# Altium schematic sheet Power.SchDoc(Power)
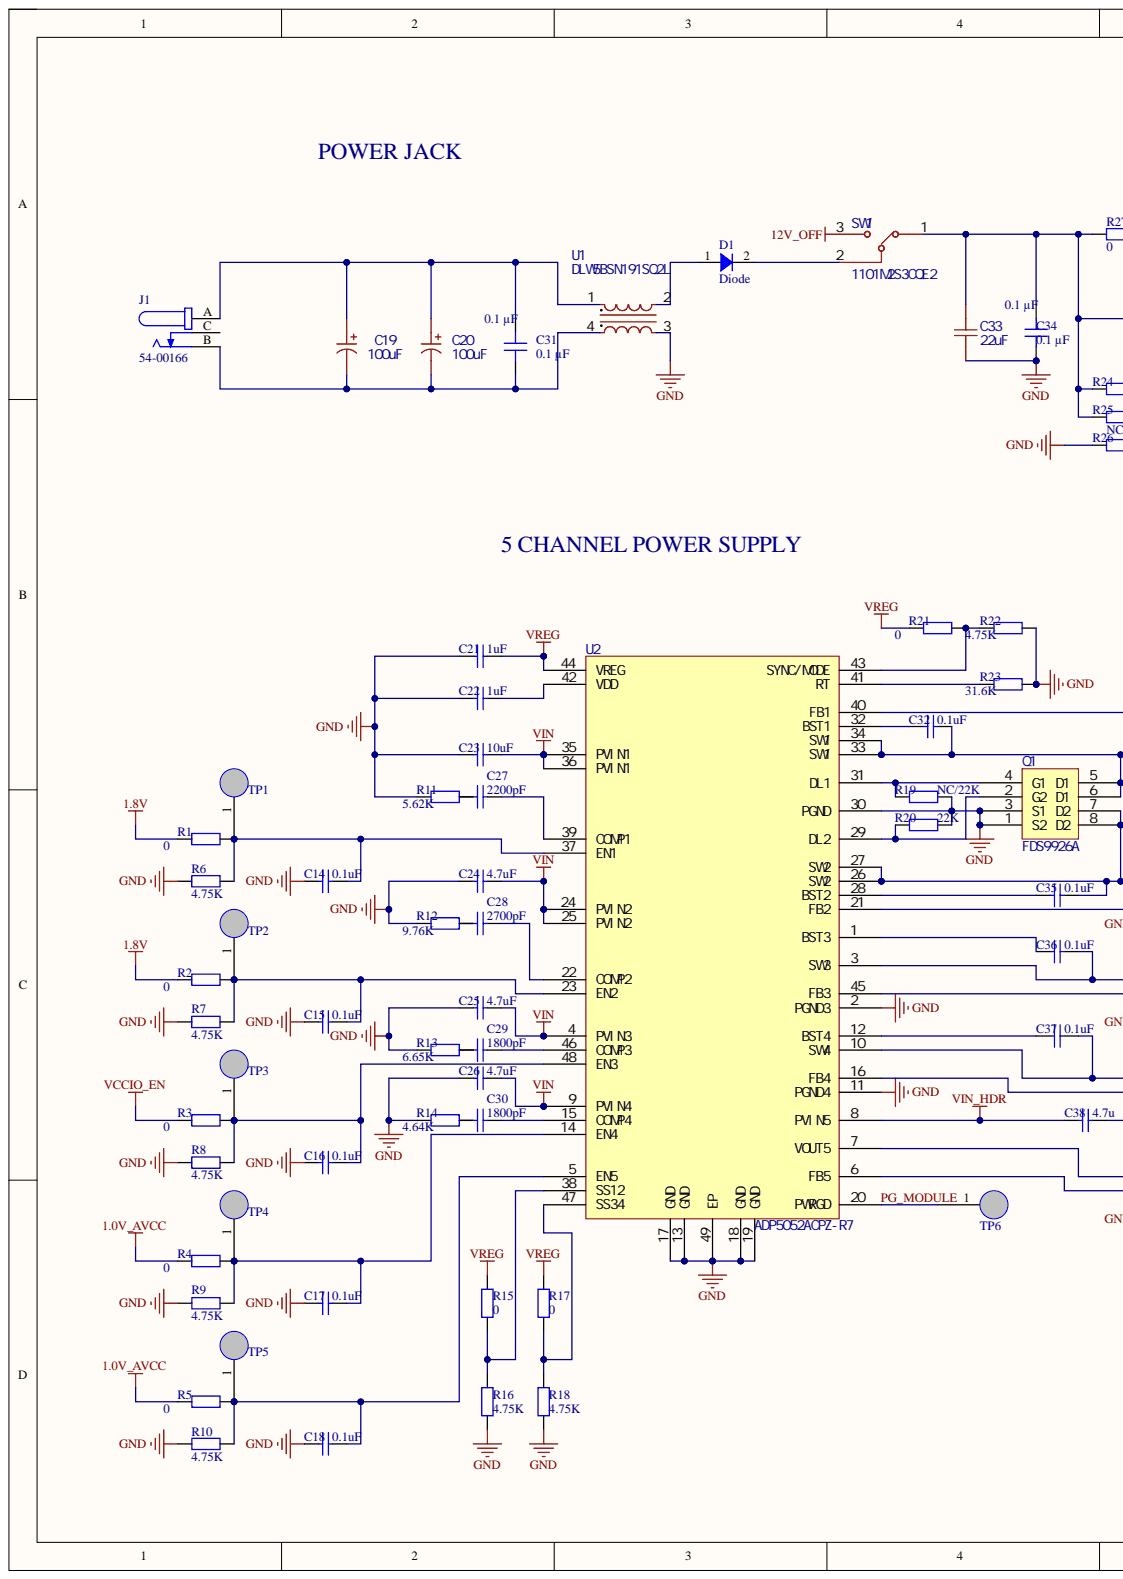
[diagram p0: Power.SchDoc, left]
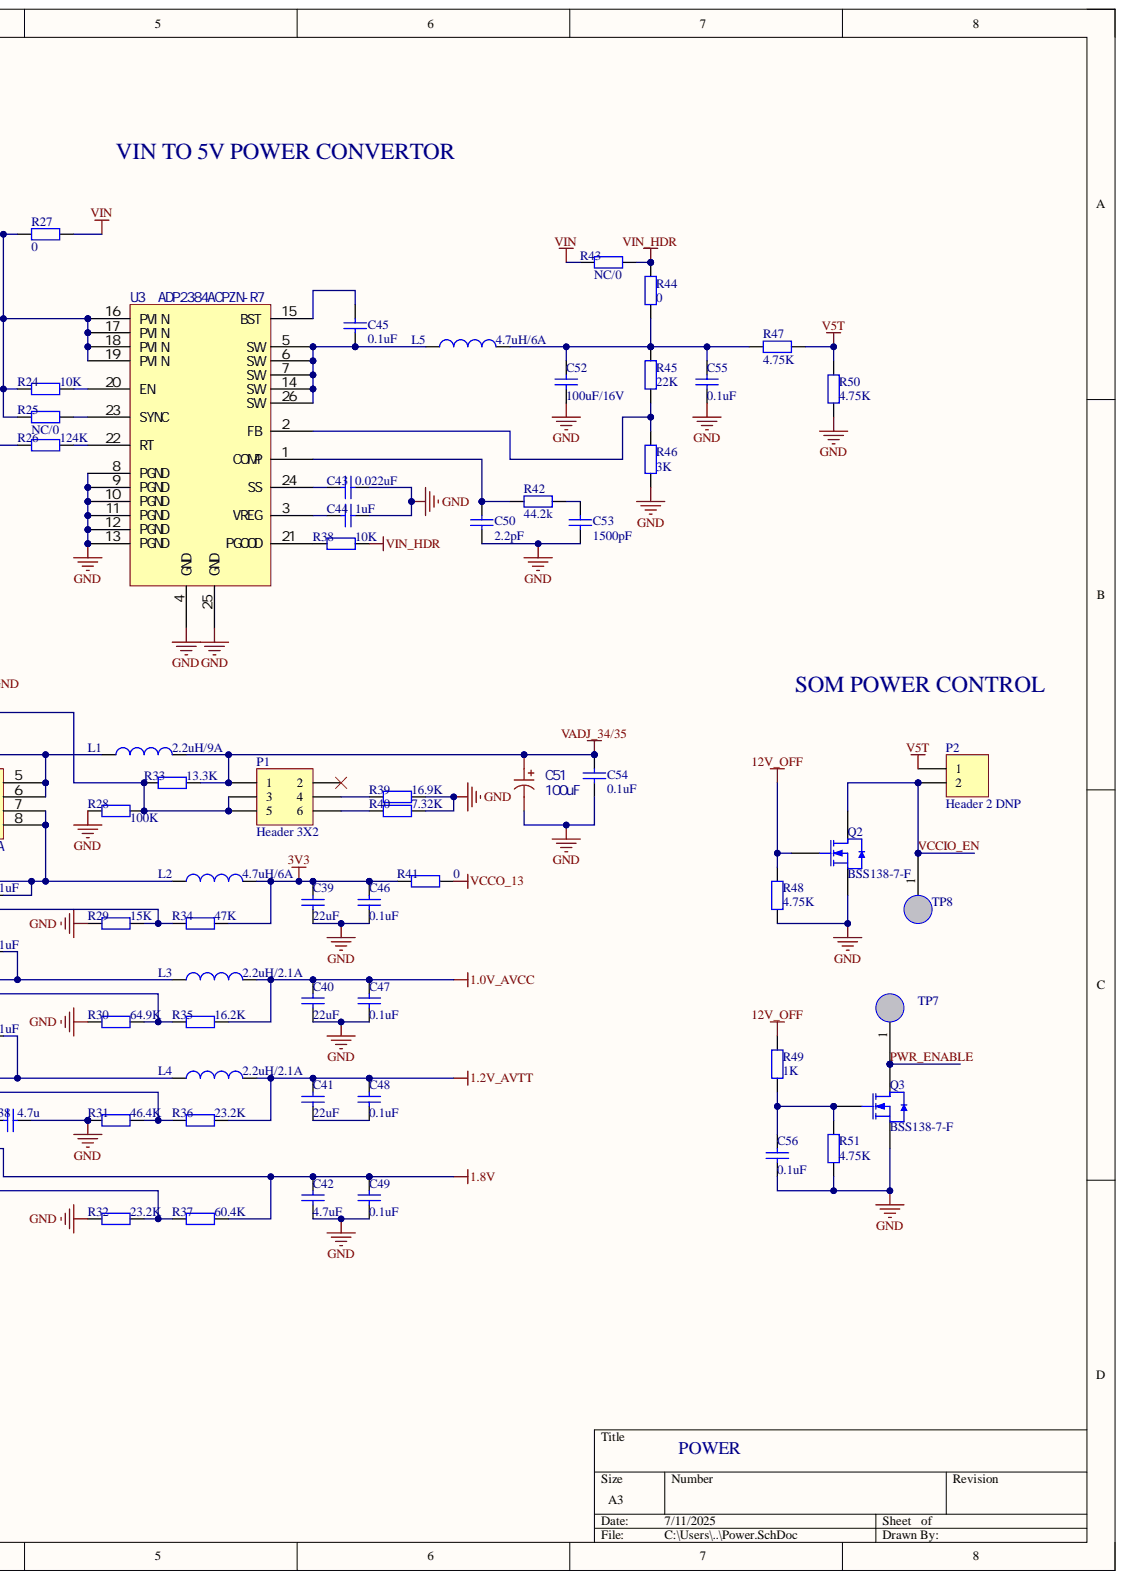
[diagram p1: Power.SchDoc, right]

## Parts
C14  value 0.1uF  [0603_C]  (hidden comment: Cap)
C15  value 0.1uF  [0603_C]  (hidden comment: Cap)
C16  value 0.1uF  [0603_C]  (hidden comment: Cap)
C17  value 0.1uF  [0603_C]  (hidden comment: Cap)
C18  value 0.1uF  [0603_C]  (hidden comment: Cap)
C19  100uF  [CAP-SMD_BD6.3-L6.6-W6.6-LS7.3-FD-H7.7]
C20  100uF  [CAP-SMD_BD6.3-L6.6-W6.6-LS7.3-FD-H7.7]
C21  value 1uF  [0603_C]  (hidden comment: Cap)
C22  value 1uF  [0603_C]  (hidden comment: Cap)
C23  value 10uF  [0603_C]  (hidden comment: Cap)
C24  value 4.7uF  [0603_C]  (hidden comment: Cap)
C25  value 4.7uF  [0603_C]  (hidden comment: Cap)
C26  value 4.7uF  [0603_C]  (hidden comment: Cap)
C27  value 2200pF  [0603_C]  (hidden comment: Cap)
C28  value 2700pF  [0603_C]  (hidden comment: Cap)
C29  value 1800pF  [0603_C]  (hidden comment: Cap)
C30  value 1800pF  [0603_C]  (hidden comment: Cap)
C31  0.1 µF  [CAP 0603_1608]
C32  value 0.1uF  [0603_C]  (hidden comment: Cap)
C33  22uF  [1206_C]
C34  0.1 µF  [CAP 0603_1608]
C35  value 0.1uF  [0603_C]  (hidden comment: Cap)
C36  value 0.1uF  [0603_C]  (hidden comment: Cap)
C37  value 0.1uF  [0603_C]  (hidden comment: Cap)
C38  value 4.7u  [0603_C]  (hidden comment: Cap)
C39  value 22uF  [0603_C]  (hidden comment: Cap)
C40  value 22uF  [0603_C]  (hidden comment: Cap)
C41  value 22uF  [0603_C]  (hidden comment: Cap)
C42  value 4.7uF  [0603_C]  (hidden comment: Cap)
C43  value 0.022uF  [0603_C]  (hidden comment: Cap)
C44  value 1uF  [0603_C]  (hidden comment: Cap)
C45  value 0.1uF  [0603_C]  (hidden comment: Cap)
C46  value 0.1uF  [0603_C]  (hidden comment: Cap)
C47  value 0.1uF  [0603_C]  (hidden comment: Cap)
C48  value 0.1uF  [0603_C]  (hidden comment: Cap)
C49  value 0.1uF  [0603_C]  (hidden comment: Cap)
C50  value 2.2pF  [0603_C]  (hidden comment: Cap)
C51  100uF  [CAP-SMD_BD6.3-L6.6-W6.6-LS7.3-FD-H7.7]
C52  value 100uF/16V  [0603_C]  (hidden comment: Cap)
C53  value 1500pF  [0603_C]  (hidden comment: Cap)
C54  value 0.1uF  [0603_C]  (hidden comment: Cap)
C55  value 0.1uF  [0603_C]  (hidden comment: Cap)
C56  value 0.1uF  [0603_C]  (hidden comment: Cap)
D1  Diode  [DO214AC SMA]
J1  54-00166  [54-00166]
L1  value 2.2uH/9A  [IND-SMD_L4.1-W4.1_SPM40XX]  (hidden comment: Inductor)
L2  value 4.7uH/6A  [IND-SMD_L7.0-W6.6]  (hidden comment: Inductor)
L3  value 2.2uH/2.1A  [IND-SMD_L4.1-W4.1_SPM40XX]  (hidden comment: Inductor)
L4  value 2.2uH/2.1A  [IND-SMD_L4.1-W4.1_SPM40XX]  (hidden comment: Inductor)
L5  value 4.7uH/6A  [IND-SMD_L7.0-W6.6]  (hidden comment: Inductor)
P1  Header 3X2  [HDR2X3]
P2  Header 2 DNP  [HDR1X2]
Q1  FDS9926A  [SOP-8]
Q2  BSS138-7-F  [SOT-23-3L]
Q3  BSS138-7-F  [SOT-23-3L]
R1  value 0  [0603_R]  (hidden comment: Res2)
R2  value 0  [0603_R]  (hidden comment: Res2)
R3  value 0  [0603_R]  (hidden comment: Res2)
R4  value 0  [0603_R]  (hidden comment: Res2)
R5  value 0  [0603_R]  (hidden comment: Res2)
R6  value 4.75K  [0603_R]  (hidden comment: Res2)
R7  value 4.75K  [0603_R]  (hidden comment: Res2)
R8  value 4.75K  [0603_R]  (hidden comment: Res2)
R9  value 4.75K  [0603_R]  (hidden comment: Res2)
R10  value 4.75K  [0603_R]  (hidden comment: Res2)
R11  value 5.62K  [0603_R]  (hidden comment: Res2)
R12  value 9.76K  [0603_R]  (hidden comment: Res2)
R13  value 6.65K  [0603_R]  (hidden comment: Res2)
R14  value 4.64K  [0603_R]  (hidden comment: Res2)
R15  value 0  [0603_R]  (hidden comment: Res2)
R16  value 4.75K  [0603_R]  (hidden comment: Res2)
R17  value 0  [0603_R]  (hidden comment: Res2)
R18  value 4.75K  [0603_R]  (hidden comment: Res2)
R19  value NC/22K  [0603_R]  (hidden comment: Res2)
R20  value 22K  [0603_R]  (hidden comment: Res2)
R21  value 0  [0603_R]  (hidden comment: Res2)
R22  value 4.75K  [0603_R]  (hidden comment: Res2)
R23  value 31.6K  [0603_R]  (hidden comment: Res2)
R24  value 10K  [0603_R]  (hidden comment: Res2)
R25  value NC/0  [0603_R]  (hidden comment: Res2)
R26  value 124K  [0603_R]  (hidden comment: Res2)
R27  value 0  [0603_R]  (hidden comment: Res2)
R28  value 100K  [0603_R]  (hidden comment: Res2)
R29  value 15K  [0603_R]  (hidden comment: Res2)
R30  value 64.9K  [0603_R]  (hidden comment: Res2)
R31  value 46.4K  [0603_R]  (hidden comment: Res2)
R32  value 23.2K  [0603_R]  (hidden comment: Res2)
R33  value 13.3K  [0603_R]  (hidden comment: Res2)
R34  value 47K  [0603_R]  (hidden comment: Res2)
R35  value 16.2K  [0603_R]  (hidden comment: Res2)
R36  value 23.2K  [0603_R]  (hidden comment: Res2)
R37  value 60.4K  [0603_R]  (hidden comment: Res2)
R38  value 10K  [0603_R]  (hidden comment: Res2)
R39  value 16.9K  [0603_R]  (hidden comment: Res2)
R40  value 7.32K  [0603_R]  (hidden comment: Res2)
R41  value 0  [0603_R]  (hidden comment: Res2)
R42  value 44.2k  [0603_R]  (hidden comment: Res2)
R43  value NC/0  [0603_R]  (hidden comment: Res2)
R44  value 0  [0603_R]  (hidden comment: Res2)
R45  value 22K  [0603_R]  (hidden comment: Res2)
R46  value 3K  [0603_R]  (hidden comment: Res2)
R47  value 4.75K  [0603_R]  (hidden comment: Res2)
R48  value 4.75K  [0603_R]  (hidden comment: Res2)
R49  value 1K  [0603_R]  (hidden comment: Res2)
R50  value 4.75K  [0603_R]  (hidden comment: Res2)
R51  value 4.75K  [0603_R]  (hidden comment: Res2)
SW1  1101M2S3CQE2  [SW-TH_1101M2S3CQE2]
TP1  TP  [Test-Point-0.5mm]
TP2  TP  [Test-Point-0.5mm]
TP3  TP  [Test-Point-0.5mm]
TP4  TP  [Test-Point-0.5mm]
TP5  TP  [Test-Point-0.5mm]
TP6  TP  [Test-Point-0.5mm]
TP7  TP  [Test-Point-0.5mm]
TP8  TP  [Test-Point-0.5mm]
U1  DLW5BSN191SQ2L  [FILTER-SMD_4P-L5.0-W5.0-TL_DLW5BSM302SQ2L]
U2  ADP5052ACPZ-R7  [LFCSP-48_L7.0-W7.0-P0.50-BL-EP5.5]
U3  ADP2384ACPZN-R7  [LFCSP-24_L4.0-W4.0-P0.50-BL_ADP238XACPZN-R7]

## Nets
1.0V_AVCC: C40.2 C47.2 L3.2 R4.2 R5.2 R35.1
1.2V_AVTT: C41.2 C48.2 L4.2 R36.1
1.8V: C42.2 C49.2 R1.2 R2.2 R37.1 U2.7(VOUT5)
3V3: C39.2 C46.2 L2.2 R34.1 R41.2
12V_OFF: Q2.1 R48.2 R49.2 SW1.3
GND: C14.1 C15.1 C16.1 C17.1 C18.1 C21.1 C22.1 C23.1 C24.1 C25.1 C26.1 C33.1 C34.1 C38.2 C39.1 C40.1 C42.1 C43.2 C44.2 C46.1 C47.1 C49.1 C50.1 C51.2 C52.1 C53.1 C54.1 C55.1 C56.1 Q1.1(S2) Q1.3(S1) Q2.2 Q3.2 R6.2 R7.2 R8.2 R9.2 R10.2 R11.2 R12.2 R13.2 R14.2 R16.2 R18.2 R19.1 R20.1 R22.1 R23.1 R26.2 R28.2 R29.2 R30.2 R31.2 R32.2 R39.1 R40.1 R46.2 R48.1 R50.1 R51.1 U1.3 U2.2(PGND3) U2.11(PGND4) U2.13(GND) U2.17(GND) U2.18(GND) U2.19(GND) U2.30(PGND) U2.49(EP) U3.4(GND) U3.8(PGND) U3.9(PGND) U3.10(PGND) U3.11(PGND) U3.12(PGND) U3.13(PGND) U3.25(GND)
V5T: P2.1 R47.2 R50.2
VADJ_34/35: C51.1 C54.2 L1.2 P1.1 R33.1
VCCO_13: R41.1
VIN: C23.2 C24.2 C25.2 C26.2 R27.1 R43.2 U2.4(PVIN3) U2.9(PVIN4) U2.24(PVIN2) U2.25(PVIN2) U2.35(PVIN1) U2.36(PVIN1)
VIN_HDR: C38.1 R38.2 R43.1 R44.1 U2.8(PVIN5)
VREG: C21.2 R15.1 R17.1 R21.2 U2.44(VREG)
PG_MODULE: TP6.1 U2.20(PWRGD)
PWR_ENABLE: Q3.3 TP7.1
VCCIO_EN: P2.2 Q2.3 R3.2 TP8.1
NetC14_2: C14.2 R1.1 R6.1 TP1.1 U2.37(EN1)
NetC15_2: C15.2 R2.1 R7.1 TP2.1 U2.23(EN2)
NetC16_2: C16.2 R3.1 R8.1 TP3.1 U2.48(EN3)
NetC17_2: C17.2 R4.1 R9.1 TP4.1 U2.14(EN4)
NetC18_2: C18.2 R5.1 R10.1 TP5.1 U2.5(EN5)
NetC19_1: C19.1 C20.1 C31.2 J1.A U1.1
NetC19_2: C19.2 C20.2 C31.1 J1.B U1.4
NetC22_2: C22.2 U2.42(VDD)
NetC27_2: C27.2 U2.39(COMP1)
NetC28_2: C28.2 U2.22(COMP2)
NetC29_2: C29.2 U2.46(COMP3)
NetC30_2: C30.2 U2.15(COMP4)
NetC32_1: C32.1 U2.32(BST1)
NetC32_2: C32.2 L1.1 Q1.5(D1) Q1.6(D1) U2.33(SW1) U2.34(SW1)
NetC33_2: C33.2 C34.2 R24.2 R25.2 R27.2 SW1.1 U3.16(PVIN) U3.17(PVIN) U3.18(PVIN) U3.19(PVIN)
NetC35_1: C35.1 U2.28(BST2)
NetC35_2: C35.2 L2.1 Q1.7(D2) Q1.8(D2) U2.26(SW2) U2.27(SW2)
NetC36_1: C36.1 U2.1(BST3)
NetC36_2: C36.2 L3.1 U2.3(SW3)
NetC37_1: C37.1 U2.12(BST4)
NetC37_2: C37.2 L4.1 U2.10(SW4)
NetC41_1: C41.1 C48.1
NetC43_1: C43.1 U3.24(SS)
NetC44_1: C44.1 U3.3(VREG)
NetC45_1: C45.1 L5.1 U3.5(SW) U3.6(SW) U3.7(SW) U3.14(SW) U3.26(SW)
NetC45_2: C45.2 U3.15(BST)
NetC50_2: C50.2 R42.1 U3.1(COMP)
NetC52_2: C52.2 C55.2 L5.2 R44.2 R45.1 R47.1
NetC53_2: C53.2 R42.2
NetC56_2: C56.2 Q3.1 R49.1 R51.2
NetD1_1: D1.1 U1.2
NetD1_2: D1.2 SW1.2
NetP1_3: P1.3 P1.5 R28.1 R33.2 U2.40(FB1)
NetP1_4: P1.4 R39.2
NetP1_6: P1.6 R40.2
NetQ1_2: Q1.2(G2) R20.2 U2.29(DL2)
NetQ1_4: Q1.4(G1) R19.2 U2.31(DL1)
NetR15_2: R15.2 R16.1 U2.38(SS12)
NetR17_2: R17.2 R18.1 U2.47(SS34)
NetR21_1: R21.1 R22.2 U2.43(SYNC/MODE)
NetR23_2: R23.2 U2.41(RT)
NetR24_1: R24.1 U3.20(EN)
NetR25_1: R25.1 U3.23(SYNC)
NetR26_1: R26.1 U3.22(RT)
NetR29_1: R29.1 R34.2 U2.21(FB2)
NetR30_1: R30.1 R35.2 U2.45(FB3)
NetR31_1: R31.1 R36.2 U2.16(FB4)
NetR32_1: R32.1 R37.2 U2.6(FB5)
NetR38_1: R38.1 U3.21(PGOOD)
NetR45_2: R45.2 R46.1 U3.2(FB)

Not connected: C27 1; C28 1; C29 1; C30 1; J1 C; P1 2; R11 1; R12 1; R13 1; R14 1

## Library data of the parts
C14-C18 C21-C30 C32 C35-C50 C52-C56 = Cap: Capacitor; Component Kind: Standard; LatestRevisionDate: 17-Jul-2002; LatestRevisionNote: Re-released for DXP Platform.; Library Name: Miscellaneous Devices.IntLib; PackageDescription: Radial Cap, Thru-Hole; 2 Leads; 0.3 in Pin Spacing; PackageReference: RAD-0.3; Published: 8-Jun-2000; Signal Integrity: Cap; Simulation: CAP; Publisher: Altium Limited
C19 C20 C51 = JVJ25V100M6x5: Manufacturer: 捷而瑞; Add into BOM: yes; Ripple Current: 72mA@120Hz; Tolerance: ±20%; Voltage Rating: 25V; Component Kind: Standard; Convert to PCB: yes; Datasheet: https://atta.szlcsc.com/upload/public/pdf/source/20250526/372E583B5965CEB57A276EA554306514.pdf; Device: JVJ25V100M6x5; Diameter: 6.3mm; Height - Seated (Max): 5.4mm; JLCPCB Part Class: 扩展库; LCSC Part Name: 100uF ±20% 25V; Lifetime: -; Manufacturer Part: JVJ25V100M6x5; Operating Temperature: -55℃~+105℃; Supplier: LCSC; Supplier Footprint: SMD,D6.3xL5.4mm; Supplier Part: C46550402; Symbol: JVJ25V100M6X5; Value: 100uF
C31 C34 = CC0603KRX7R9BB104: CAP CER 0.1UF 50V X7R 0603; manufacturer: Yageo; Database Table Name: Capacitors - Ceramic - 0603; Tolerance: ±10%; Applications: General Purpose; Capacitance: 0.1 µF; Component Kind: Standard; componentlink1description: Datasheet; componentlink1url: https://www.yageo.com/upload/media/product/productsearch/datasheet/mlcc/UPY-GPHC_X7R_6.3V-to-50V_18.pdf; componentlink2description: DigiKey Link; componentlink2url: https://www.digikey.com/en/products/detail/yageo/CC0603KRX7R9BB104/2103082; Device Package: 0603 (1608 Metric); lastupdated: 2024/2/9 20:10:21; Library Name: Celestial Altium Library - altium_library.DbLib; Lifecycle Status: Active; Minimum Order: 1; Mounting Type: Surface Mount, MLCC; Operating Temperature: -55°C ~ 125°C; Package / Case: 0603 (1608 Metric); packaging: TapeAndReel; Part Number: CC0603KRX7R9BB104; Part Status: Active; partid: 9506; price: 0.01; series: CC; Size / Dimension: 0.063" L x 0.031" W (1.60mm x 0.80mm); Supplier 1: DigiKey; Supplier Part Number 1: 311-1344-1-ND; Temperature Coefficient: X7R; Thickness (Max): 0.035" (0.90mm); value: 0.1 µF; Voltage - Rated: 50V
C33 = CGA0805X5R226M250MT: Manufacturer: HRE(芯声); Add into BOM: yes; Temperature Coefficient: X5R; Tolerance: ±20%; Voltage Rating: 25V; Component Kind: Standard; Convert to PCB: yes; Device: CGA0805X5R226M250MT; JLCPCB Part Class: 扩展库; LCSC Part Name: 22uF ±20% 25V; Manufacturer Part: CGA0805X5R226M250MT; PCB3D: C0805_L2.0-W1.3-H1.3; Supplier: LCSC; Supplier Footprint: 0805; Supplier Part: C6119899; Symbol: CGA0805X5R226M250MT; Value: 22uF
D1 = B330A-13-F: DIODE SCHOTTKY 30V 3A SMA; Manufacturer: Diodes Incorporated; Capacitance @ Vr, F: 200pF @ 4V, 1MHz; Current - Reverse Leakage @ Vr: 500 µA @ 30 V; Database Table Name: Diodes - Rectifiers - Single; Speed: Fast Recovery =< 500ns, > 200mA (Io); Voltage - Forward (Vf) (Max) @ If: 500 mV @ 3 A; Component Kind: Standard; ComponentLink1Description: Datasheet; ComponentLink1URL: https://www.diodes.com/assets/Datasheets/ds30891.pdf; ComponentLink2Description: DigiKey Link; ComponentLink2URL: https://www.digikey.com/en/products/detail/diodes-incorporated/B330A-13-F/814951; Current - Average Rectified (Io): 3A; Diode Type: Schottky; LastUpdated: 2024/6/3 19:54:31; Library Name: Celestial Altium Library - altium_library.DbLib; Lifecycle Status: Active; Minimum Order: 1; Mounting Type: Surface Mount; Operating Temperature - Junction: -55°C ~ 150°C; Package / Case: DO-214AC, SMA; Packaging: TapeAndReel; Part Number: B330A-13-F; Part Status: Active; PartId: 2073; Price: 0.11; Series: -; Supplier 1: DigiKey; Supplier Device Package: SMA; Supplier Part Number 1: B330A-FDICT-ND; Voltage - DC Reverse (Vr) (Max): 30 V
L1-L5 = Inductor: Code_IEC: 1005; Code_JEITA: 0402; Component Kind: Standard; Description: Inductor; LatestRevisionDate: 29-May-2009; LatestRevisionNote: IPC-7351 Footprint Added.; Library Name: Miscellaneous Devices.IntLib; PackageDescription: Chip Inductor; PackageReference: 0402-A; Published: 8-Jun-2000; Publisher: Altium Limited
P1 = Header 3X2: Header, 3-Pin, Dual row; Component Kind: Standard; LatestRevisionDate: 17-Jul-2002; LatestRevisionNote: Re-released for DXP Platform.; Library Name: Miscellaneous Connectors.IntLib; Signal Integrity: Connector; Publisher: Altium Limited
P2 = Header 2: Header, 2-Pin; Component Kind: Standard; LatestRevisionDate: 17-Jul-2002; LatestRevisionNote: Re-released for DXP Platform.; Library Name: Miscellaneous Connectors.IntLib; Signal Integrity: Connector; Publisher: Altium Limited
Q1 = FDS9926A: Manufacturer: onsemi(安森美); Add into BOM: yes; Continuous Drain Current (Id): 6.5A; Drain Source On Resistance (RDS(on)@Vgs,Id): 30mΩ@6.5A,4.5V; Drain Source Voltage (Vdss): 20V; Gate Threshold Voltage (Vgs(th)@Id): 1.5V@250uA; Input Capacitance (Ciss@Vds): 650pF@10V; Power Dissipation (Pd): 900mW; Symbol: FDS9926A_C903644; Total Gate Charge (Qg@Vgs): 9nC@4.5V; Type: 2个N沟道; Component Kind: Standard; Convert to PCB: yes; Datasheet: https://atta.szlcsc.com/upload/public/pdf/source/20210715/C903644_027AD27A39678D672DE7DFBE48C0358E.pdf; Device: FDS9926A; JLCPCB Part Class: 扩展库; LCSC Part Name: 2个N沟道 耐压:20V 电流:6.5A; Library Name: 1_P1.SCHLIB; Manufacturer Part: FDS9926A; Operating Temperature: -55℃~+150℃@(Tj); Reverse Transfer Capacitance (Crss@Vds): -; Supplier: LCSC; Supplier Footprint: SOP-8; Supplier Part: C903644
Q2 Q3 = AO3400A N道沟 X0YV1T SOT-23: MOS(场效应管); Component Kind: Standard; ComponentLink1Description: 供应商链接; ComponentLink1URL: http://www.szlcsc.com/product/details_21629.html; Library Name: Miscellaneous Devices LC.IntLib; Package: SOT-23; Supplier: LC; SuppliersPartNumber: C20917
R1-R51 = Res2: Resistor; Component Kind: Standard; LatestRevisionDate: 17-Jul-2002; LatestRevisionNote: Re-released for DXP Platform.; Library Name: Miscellaneous Devices.IntLib; PackageDescription: Axial Device, Thru-Hole; 2 Leads; 0.4 in Pin Spacing; PackageReference: AXIAL-0.4; Published: 8-Jun-2000; Signal Integrity: Res; Simulation: RESISTOR; Publisher: Altium Limited
SW1 = 1101M2S3CQE2: Manufacturer: C&K; Actuator Color: 黑色; Actuator Style: 矩形柱状; Add into BOM: yes; Circuit: 单刀双掷; Current Rating: 6A; Life: 4万次; Voltage Rating: 120V; Component Kind: Standard; Convert to PCB: yes; Datasheet: https://atta.szlcsc.com/upload/public/pdf/source/20210326/C221537_FD019EC59B82E7FB82BB4E8D2D006891.pdf; Device: 1101M2S3CQE2; JLCPCB Part Class: 扩展库; LCSC Part Name: 滑动开关 Slide Switch; Library Name: 1_P1.SCHLIB; Manufacturer Part: 1101M2S3CQE2; Mounting Type: 直插; Operating Temperature: -30℃~+65℃; PCB3D: SW-TH_1101M2S3CQE2; Supplier: LCSC; Supplier Footprint: 插件; Supplier Part: C221538; Symbol: 1101M2S3CQE2; Termination Style: PC引脚
U1 = DLW5BSN191SQ2L: Manufacturer: muRata(村田); Add into BOM: yes; Current Rating: 5A; DC Resistance(DCR): 20mΩ; Impedance @ Frequency: 190Ω@100MHz; Insulation Resistance(IR): 10MΩ; Voltage Rating - DC: 50V; Voltage Withstand: 125V; Component Kind: Standard; Convert to PCB: yes; Datasheet: https://atta.szlcsc.com/upload/public/pdf/source/20230606/B6C499D25676E8FF4A4D82CF93BE6C71.pdf; Device: DLW5BSN191SQ2L; JLCPCB Part Class: 扩展库; LCSC Part Name: DLW5BSN191SQ2L; Manufacturer Part: DLW5BSN191SQ2L; Operating Temperature: -40℃~+85℃; PCB3D: FILTER-SMD_4P-L5.0-W5.0-H4.5-TL_DLW5BSM302SQ2L; Supplier: LCSC; Supplier Footprint: SMD-4P,5x5mm; Supplier Part: C5205004; Symbol: DLW5BSN191SQ2L
U2 = ADP5052ACPZ-R7: Manufacturer: ADI(亚德诺); Add into BOM: yes; Component Kind: Standard; Convert to PCB: yes; Datasheet: https://atta.szlcsc.com/upload/public/pdf/source/20201015/C459150_C89F4A8152A4A281A28DC1821A423B97.pdf; Device: ADP5052ACPZ-R7; JLCPCB Part Class: 扩展库; LCSC Part Name: 5通道集成式电源解决方案; Library Name: 1_P1.SCHLIB; Manufacturer Part: ADP5052ACPZ-R7; PCB3D: LFCSP-48_L7.0-W7.0-H0.9-P0.50-BL-EP5.5; Supplier: LCSC; Supplier Footprint: LFCSP-48(7x7); Supplier Part: C459150; Symbol: ADP5052ACPZ-R7
U3 = ADP2384ACPZN-R7: Manufacturer: ADI(亚德诺); Add into BOM: yes; Frequency - Switching: 200kHz~1.4MHz; Function: 降压型; Number of Outputs: 1; Output Current: 4A; Switch tube (built-in/external): 内置; Synchronous Rectifier: 是; Topology: 降压式; Voltage - Supply: 4.5V~20V; Component Kind: Standard; Convert to PCB: yes; Datasheet: https://atta.szlcsc.com/upload/public/pdf/source/20180424/C208277_E3FB7E20F1B92FC337461D835F81F912.pdf; Device: ADP2384ACPZN-R7; JLCPCB Part Class: 扩展库; LCSC Part Name: 20V、4A同步降压DC-DC稳压器; Library Name: 1_P1.SCHLIB; Manufacturer Part: ADP2384ACPZN-R7; Operating Temperature: -40℃~+125℃; Output Type: -; Output Voltage: -; PCB3D: LFCSP-24_L4.0-W4.0-H0.8-P0.50-BL_ADP238XACPZN-R7; Quiescent Current: -; Supplier: LCSC; Supplier Footprint: LFCSP-24(4x4); Supplier Part: C208277; Symbol: ADP2384ACPZN-R7
J1 = 54-00166: Component Kind: Standard; Library Name: PCB.SchLib
TP1-TP8 = TP: Component Kind: Standard; Library Name: USB_UART.SCHLIB
